# Altium schematic sheet P03_UART_Debug.SchDoc
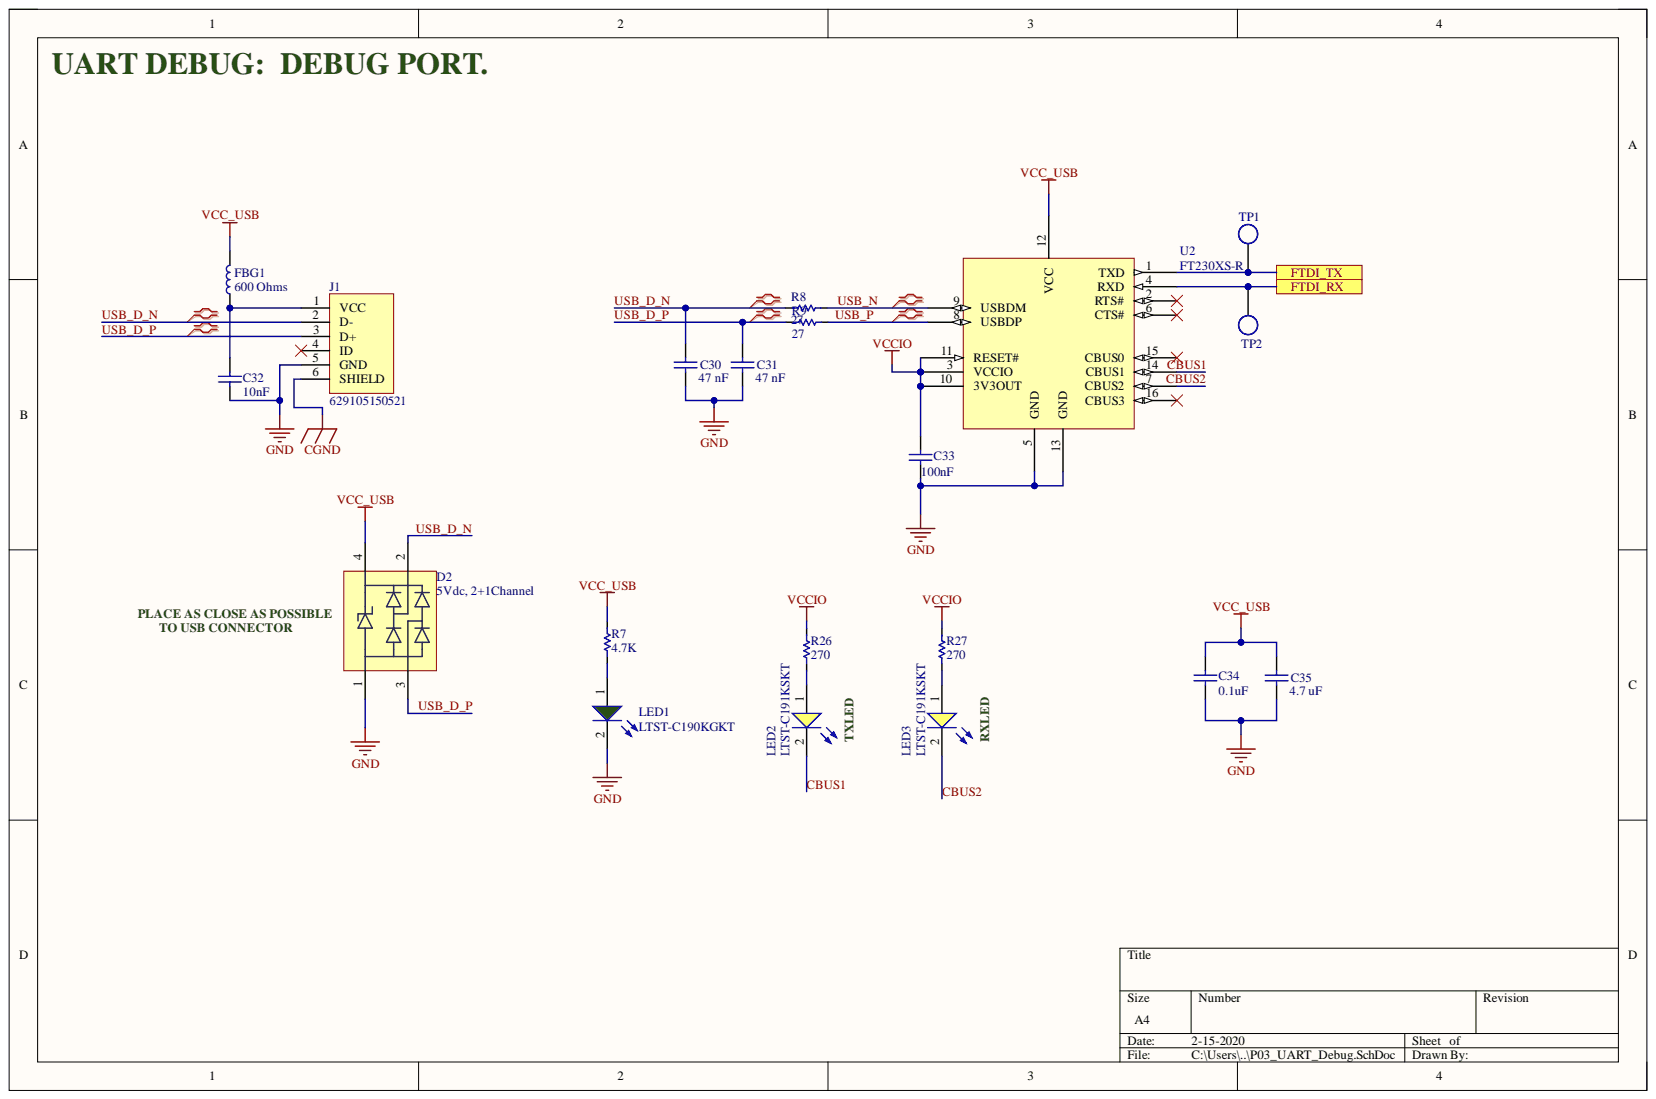
[diagram p0: P03_UART_Debug.SchDoc]

## Parts
C30  47 nF
C31  47 nF
C32  10nF  [CAP_0603]
C33  100nF  [CAP_0603]
C34  0.1uF  [CAP_0603]
C35  4.7 uF
D2  5Vdc, 2+1Channel  [SOT143-4L]
FBG1  600 Ohms  [CAP_0603]
J1  629105150521  [629105150521]
LED1  LTST-C190KGKT
LED2  LTST-C191KSKT  [LED_0603]
LED3  LTST-C191KSKT  [LED_0603]
R7  4.7K  [RES_0603]
R8  27  [RES_0603]
R9  27  [RES_0603]
R26  270  [RES_0603]
R27  270  [RES_0603]
TP1  TP_SMD  [TP_SMD]  (value not drawn)
TP2  TP_SMD  [TP_SMD]  (value not drawn)
U2  FT230XS-R  [SOP64P600X175-16N]

## Ports
FTDI_RX, FTDI_TX

## Nets
CGND: J1.6(SHIELD)
GND: C30.2 C31.2 C32.1 C33.1 C34.1 C35.1 D2.1 J1.5(GND) LED1.2 U2.5(GND) U2.13(GND)
VCC_USB: C34.2 C35.2 D2.4 FBG1.2 R7.2 U2.12(VCC)
VCCIO: C33.2 R26.2 R27.2 U2.3(VCCIO) U2.10(3V3OUT) U2.11(RESET#)
CBUS1: LED2.2 U2.14(CBUS1)
CBUS2: LED3.2 U2.7(CBUS2)
FTDI_RX: TP2.0 U2.4(RXD) ; port FTDI_RX
FTDI_TX: TP1.0 U2.1(TXD) ; port FTDI_TX
USB_D_N: C30.1 D2.2 J1.2(D-) R8.1
USB_D_P: C31.1 D2.3 J1.3(D+) R9.1
USB_N: R8.2 U2.9(USBDM)
USB_P: R9.2 U2.8(USBDP)
NetC32_2: C32.2 FBG1.1 J1.1(VCC)
NetLED1_1: LED1.1 R7.1
NetLED2_1: LED2.1 R26.1
NetLED3_1: LED3.1 R27.1

Not connected: J1 4(ID); U2 2(RTS#) 6(CTS#) 15(CBUS0) 16(CBUS3)

## Library data of the parts
C30 C31 = C0603C473K4RACTU: CAP CER 0.047UF 16V X7R 0603; Manufacturer: KEMET; Rated Voltage: 16 VDC; Tolerance: 10%; Component Kind: Standard; ComponentLink1Description: Manufacturer; ComponentLink1URL: http://www.we-online.de; ComponentLink2Description: Datasheet; ComponentLink2URL: https://katalog.we-online.com/pbs/datasheet/865080345012.pdf; Datasheet: https://api.kemet.com/component-edge/download/datasheet/C0603C473K4RACTU.pdf; Manufacturer Part No.: C0603C473K4RACTU; Mounting Technology: SMD; Package Description: 0603; Package Reference: CAP_0603; Supplier: Digi-Key; Supplier Part No.: 399-7932-2-ND; Value: 47 nF; Library Name: Felix_Sch_lib.SchLib
C32 = C0603C104M4RACTU: CAP CER 10000PF 16V NPO 0805; Manufacturer: Yageo; Manufacturer Part No.: CC0805JKNPO7BN103; Ceramic Type: NP0; Tolerance: 5%; Component Kind: Standard; ComponentLink1Description: Manufacturer; ComponentLink1URL: http://www.we-online.de; ComponentLink2Description: Datasheet; ComponentLink2URL: https://content.kemet.com/datasheets/KEM_C1002_X7R_SMD.pdf; Datasheet: https://www.yageo.com/upload/media/product/productsearch/datasheet/mlcc/UPY-GP_NP0_16V-to-50V_18.pdf; Mounting Technology: SMD; Package Description: 0805; Package Reference: CAP_0805; Rated Voltage: 16V; Supplier: Digi-Key; Supplier Part No.: 311-4205-1-ND; Value: 10nF; Library Name: Felix_Sch_lib.SchLib
C33 = C0603C104M4RACTU: CAP CER 100PF 16V NP0 0603; Manufacturer: KEMET; Manufacturer Part No.: C0603C101J4GAC7867; Ceramic Type: X7R; Tolerance: 5%; Component Kind: Standard; ComponentLink1Description: Manufacturer; ComponentLink1URL: http://www.we-online.de; ComponentLink2Description: Datasheet; ComponentLink2URL: https://content.kemet.com/datasheets/KEM_C1002_X7R_SMD.pdf; Datasheet: https://api.kemet.com/component-edge/download/datasheet/C0603C101J4GACTU.pdf; Mounting Technology: SMD; Package Description: 0603; Package Reference: CAP_0603; Rated Voltage: 16V; Supplier: Digi-Key; Supplier Part No.: 399-14476-1-ND; Value: 100nF; Library Name: Felix_Sch_lib.SchLib
C34 = C0603C104K4PAC7867: CAP CER 0.1UF 16V X5R 0603; Manufacturer: KEMET; Tolerance: 5%; Ceramic Type: X5R; Component Kind: Standard; ComponentLink1Description: Manufacturer; ComponentLink1URL: http://www.we-online.de; ComponentLink2Description: Datasheet; ComponentLink2URL: https://content.kemet.com/datasheets/KEM_C1002_X7R_SMD.pdf; Datasheet: https://api.kemet.com/component-edge/download/datasheet/C0603C104K4PAC7867.pdf; Manufacturer Part No.: C0603C104K4PAC7867; Mounting Technology: SMD; Package Description: 0603; Package Reference: CAP_0603; Rated Voltage: 16V; Supplier: Digi-Key; Supplier Part No.: 399-14941-1-ND; Value: 0.1uF; Library Name: Felix_Sch_lib.SchLib
C35 = C0603C473K4RACTU_1: CAP CER 4.7UF 16V X5R 0603; Manufacturer: Murata Electronics; Manufacturer Part No.: GRM188R61C475KAAJD; Rated Voltage: 16 VDC; Tolerance: 10%; Component Kind: Standard; ComponentLink1Description: Manufacturer; ComponentLink1URL: http://www.we-online.de; ComponentLink2Description: Datasheet; ComponentLink2URL: https://katalog.we-online.com/pbs/datasheet/865080345012.pdf; Datasheet: https://search.murata.co.jp/Ceramy/image/img/A01X/G101/ENG/GRM188R61C475KAAJ-01.pdf; Mounting Technology: SMD; Package Description: 0603; Package Reference: CAP_0603; Supplier: Digi-Key; Supplier Part No.: 490-10481-1-ND; Value: 4.7 uF; Library Name: Felix_Sch_lib.SchLib
D2 = 8240026: TVS DIODE 5V 9V SOT143-4 ESD; Manufacturer: Wurth Elektronik; Channel: 2+1; Channel Input Capacitance: 1.2pF; Reverse Breakdown Voltage min.: 6V; Reverse Channel Leakage Current: 1uA; Reverse Peak Pulse Current: 6A; Component Kind: Standard; ComponentLink1Description: Manufacturer; ComponentLink1URL: http://www.we-online.de; ComponentLink2Description: Datasheet; ComponentLink2URL: https://katalog.we-online.com/pbs/datasheet/8240026.pdf; Datasheet: https://www.we-online.de/katalog/datasheet/8240026.pdf; DC Operating Voltage: 5V; Manufacturer Part No.: 8240026; Mounting Technology: SMD; Package Description: SOT143-4; Supplier: Wurth Elektronik; Supplier Part No.: 8240026; Library Name: OSD335-X_Devboard.SchLib
FBG1 = Ferrite Bead Generic: 600 Ohms @ 100MHz Ferrite Bead 0603 2A 100mOhm; Manufacturer: Chilisin Electronics; Manufacturer Part No.: BBHV00160808601Y00; Component Kind: Standard; ComponentLink1Description: Manufacturer; ComponentLink1URL: http://www.we-online.de; ComponentLink2Description: Datasheet; ComponentLink2URL: https://content.kemet.com/datasheets/KEM_C1002_X7R_SMD.pdf; Datasheet: https://www.chilisin.com/upload/media/product/emi_bead/file/BBHV_Series.pdf; Mounting Technology: SMD; Package Description: 0603; Package Reference: FB_0603; Rated Current: 2 A; Supplier: Digi-Key; Supplier Part No.: 2184-BBHV00160808601Y00CT-ND; Tolerance: -; Value: 600 Ohms; Library Name: Felix_Sch_lib.SchLib
J1 = 629105150521: CONN RCPT USB2.0 MICRO B SMD R/A; Manufacturer: Wurth Elektronik; ComponentLink1 Description: Manufacturer; ComponentLink2 Description: Datasheet; Component Kind: Standard; ComponentLink1URL: http://www.we-online.de; ComponentLink2URL: http://katalog.we-online.de/em/datasheet/629105150521.pdf; Datasheet: https://www.we-online.de/katalog/datasheet/629105150521.pdf; Manufacturer Part No.: 629105150521; Mounting Technology: THRU/SMD; Package Description: MICRO USB TYPE B DEVICE RECEPTACLE; Package Reference: 629105150521; Supplier: Wurth Elektronik; Supplier Part No.: 629105150521; Library Name: OSD335-X_Devboard.SchLib
LED1 = LTST-C190KGKT: LED GREEN CLEAR CHIP SMD 0603; Manufacturer: Lite-On Inc.; Test Current: 20 mA; Vf: 2V; Color: Green; Component Kind: Standard; ComponentLink1Description: Manufacturer; ComponentLink1URL: http://www.we-online.de; ComponentLink2Description: Datasheet; ComponentLink2URL: http://katalog.we-online.com/led/datasheet/150080BS75000.pdf; Datasheet: https://www.we-online.de/katalog/datasheet/150080BS75000.pdf; Manufacturer Part No.: LTST-C190KGKT; Mounting Technology: SMD; Supplier: Digi-Key; Supplier Part No.: 160-1435-1-ND; Library Name: OSD335-X_Devboard.SchLib
LED2 LED3 = LTST-C190KGKT: LED YELLOW CLEAR SMD 0603; Manufacturer: Lite-On Inc.; Test Current: 20 mA; Vf: 2.1V; Color: Yellow; Component Kind: Standard; ComponentLink1Description: Manufacturer; ComponentLink1URL: http://www.we-online.de; ComponentLink2Description: Datasheet; ComponentLink2URL: http://katalog.we-online.com/led/datasheet/150080BS75000.pdf; Datasheet: http://optoelectronics.liteon.com/upload/download/DS22-2000-224/LTST-C191KSKT.PDF; Manufacturer Part No.: LTST-C191KSKT; Mounting Technology: SMD; Package Reference: LED_0603; Supplier: Digi-Key; Supplier Part No.: 160-1448-1-ND; Library Name: Felix_Sch_lib.SchLib
R7 = RC0603JR-074K7L: RES SMD 4.7K OHM 5% 1/10W 0603; Manufacturer: Yageo; Component Kind: Standard; ComponentLink1Description: Manufacturer Link; ComponentLink1URL: http://www.vishay.com/company/brands/dale/; ComponentLink2Description: Datasheet; ComponentLink2URL: http://www.vishay.com/docs/20035/dcrcwe3.pdf; Datasheet: https://www.yageo.com/upload/media/product/productsearch/datasheet/rchip/PYu-RC_Group_51_RoHS_L_10.pdf; Date: 2020; Manufacturer Part No.: RC0603JR-074K7L; Mounting Technology: SMD; Package Description: 0603; Package Reference: RES_0603; Power Rating: 1/10W; Supplier: Digikey; Supplier Part No.: 311-4.7KGRCT-ND; Tolerance: 5%; Value: 4.7K; Library Name: OSD335-X_Devboard.SchLib
R8 R9 = RC0603JR-0727RL: RES SMD 27 OHM 5% 1/10W 0603; Manufacturer: Yageo; Component Kind: Standard; ComponentLink1Description: Manufacturer Link; ComponentLink1URL: http://www.vishay.com/company/brands/dale/; ComponentLink2Description: Datasheet; ComponentLink2URL: http://www.vishay.com/docs/20035/dcrcwe3.pdf; Datasheet: https://www.yageo.com/upload/media/product/productsearch/datasheet/rchip/PYu-RC_Group_51_RoHS_L_10.pdf; Date: 2020; Manufacturer Part No.: RC0603JR-0727RL; Mounting Technology: SMD; Package Description: 0603; Package Reference: RES_0603; Supplier: Digikey; Supplier Part No.: 311-27GRCT-ND; Tolerance: 5%; Value: 27; Library Name: OSD335-X_Devboard.SchLib
R26 R27 = RC0603JR-07270RL: RES SMD 270 OHM 5% 1/10W 0603; Manufacturer: Yageo; Component Kind: Standard; ComponentLink1Description: Manufacturer Link; ComponentLink1URL: http://www.vishay.com/company/brands/dale/; ComponentLink2Description: Datasheet; ComponentLink2URL: http://www.vishay.com/docs/20035/dcrcwe3.pdf; Datasheet: https://www.yageo.com/upload/media/product/productsearch/datasheet/rchip/PYu-RC_Group_51_RoHS_L_10.pdf; Date: 2020; Manufacturer Part No.: RC0603JR-07270RL; Mounting Technology: SMD; Package Description: 0603; Package Reference: RES_0603; Power Rating: 1/10W; Supplier: Digikey; Supplier Part No.: 311-270GRCT-ND; Tolerance: 5%; Value: 270; Library Name: Felix_Sch_lib.SchLib
U2 = FT230XS-R: IC USB SERIAL BASIC UART 16SSOP; Manufacturer: FTDI, Future Technology Devices International Ltd; Component Kind: Standard; ComponentLink1Description: Datasheet; ComponentLink1URL: https://www.ftdichip.com/Support/Documents/DataSheets/ICs/DS_FT230X.pdf; Datasheet: https://www.ftdichip.com/Support/Documents/DataSheets/ICs/DS_FT230X.pdf; Manufacturer Part No.: FT230XS-R; Mounting Technology: SMD; Package Description: USB Bridge, USB to UART USB 2.0 UART Interface 16-SSOP; Package Reference: SSOP-16; Supplier: Digi-Key; Supplier Part No.: 768-1135-1-ND; Library Name: OSD335-X_Devboard.SchLib
TP1 TP2 = TP_SMD: Component Kind: Standard; Library Name: OSD335-X_Devboard.SchLib
